# Altium schematic sheet 08_SOFT_DPHY.SchDoc(08_SOFT_DPHY)
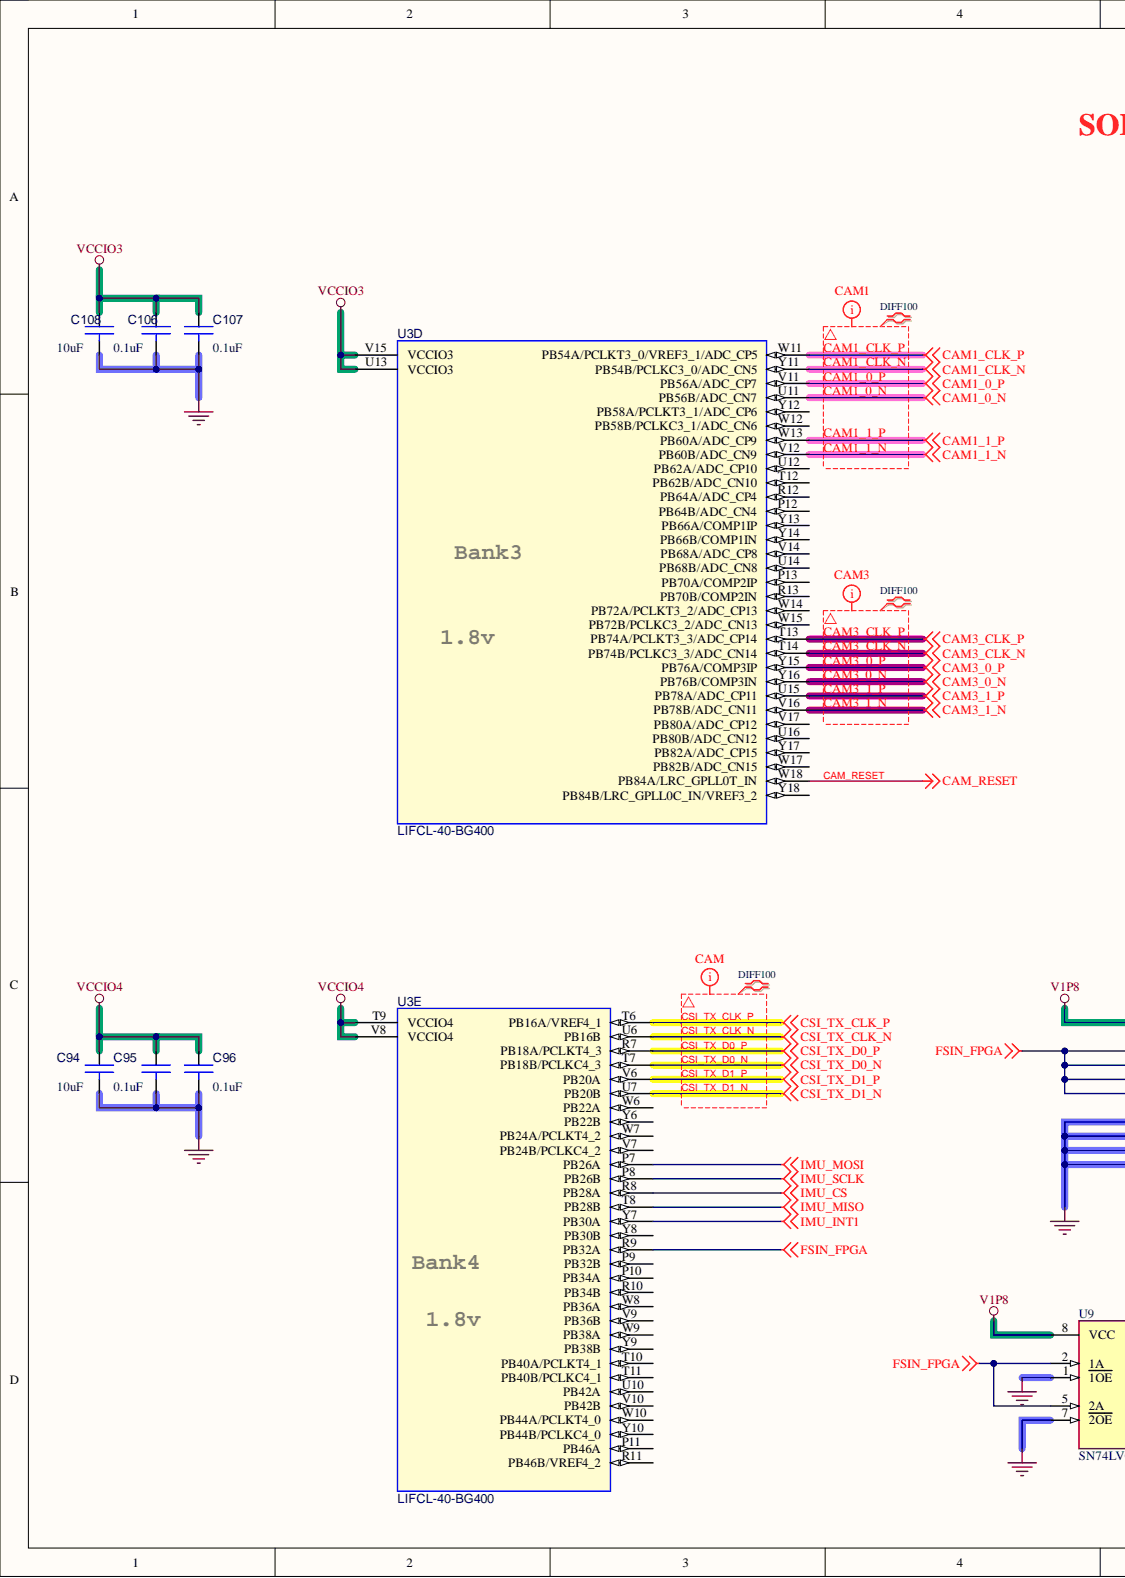
[diagram p0: 08_SOFT_DPHY.SchDoc, left]
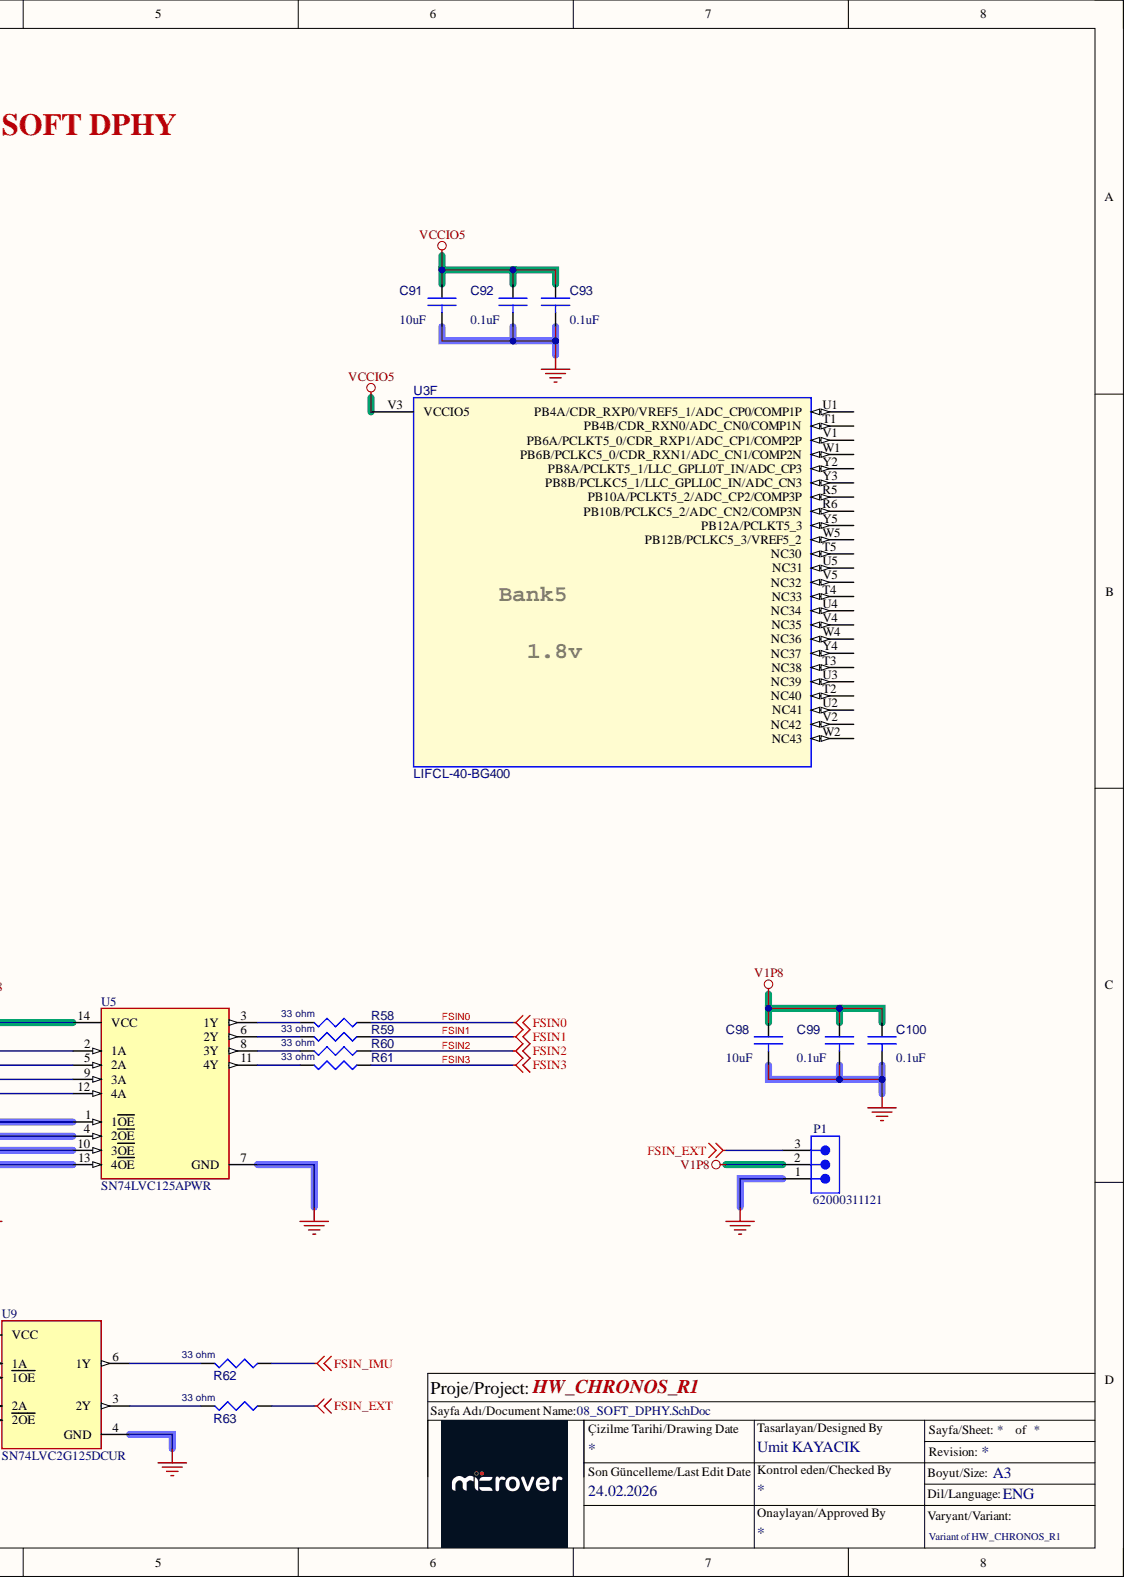
[diagram p1: 08_SOFT_DPHY.SchDoc, right]

## Parts
C91  10uF  Part Reference: C91  [C0603]
C92  0.1uF  Part Reference: C92  [C0201]
C93  0.1uF  Part Reference: C93  [C0201]
C94  10uF  Part Reference: C94  [C0603]
C95  0.1uF  Part Reference: C95  [C0201]
C96  0.1uF  Part Reference: C96  [C0201]
C98  10uF  [C0603]
C99  0.1uF  [C0201]
C100  0.1uF  [C0201]
C106  0.1uF  Part Reference: C106  [C0201]
C107  0.1uF  Part Reference: C107  [C0201]
C108  10uF  Part Reference: C108  [C0603]
P1  62000311121  [62000311121]
R58  33 ohm  [R0402]
R59  33 ohm  [R0402]
R60  33 ohm  [R0402]
R61  33 ohm  [R0402]
R62  33 ohm  [RLP-100]
R63  33 ohm  [R0402]
U3  LIFCL-40-BG400  Part Reference: U3  [LIFCL-40-BG400]  (parts D,E,F)
U5  SN74LVC125APWR  [FP-PW0014A-IPC_B]
U9  SN74LVC2G125DCUR  [FP-DCU0008A-MFG]

## Nets
FSIN_EXT: P1.3 R63.2
FSIN_FPGA: U3.R9(PB32A) U5.2(1A) U5.5(2A) U5.9(3A) U5.12(4A) U9.2(1A) U9.5(2A)
FSIN_IMU: R62.2
GND: C91.2 C92.2 C93.2 C94.2 C95.2 C96.2 C98.2 C99.2 C100.2 C106.2 C107.2 C108.2 P1.1 U5.1(1OE) U5.4(2OE) U5.7(GND) U5.10(3OE) U5.13(4OE) U9.1(1OE) U9.4(GND) U9.7(2OE)
IMU_CS: U3.R8(PB28A)
IMU_INT1: U3.Y7(PB30A)
IMU_MISO: U3.T8(PB28B)
IMU_MOSI: U3.P7(PB26A)
IMU_SCLK: U3.P8(PB26B)
V1P8: C98.1 C99.1 C100.1 P1.2 U5.14(VCC) U9.8(VCC)
VCCIO3: C106.1 C107.1 C108.1 U3.U13(VCCIO3) U3.V15(VCCIO3)
VCCIO4: C94.1 C95.1 C96.1 U3.T9(VCCIO4) U3.V8(VCCIO4)
VCCIO5: C91.1 C92.1 C93.1 U3.V3(VCCIO5)
CAM1_0_N: U3.U11(PB56B/ADC_CN7)
CAM1_0_P: U3.V11(PB56A/ADC_CP7)
CAM1_1_N: U3.V12(PB60B/ADC_CN9)
CAM1_1_P: U3.W13(PB60A/ADC_CP9)
CAM1_CLK_N: U3.Y11(PB54B/PCLKC3_0/ADC_CN5)
CAM1_CLK_P: U3.W11(PB54A/PCLKT3_0/VREF3_1/ADC_CP5)
CAM3_0_N: U3.Y16(PB76B/COMP3IN)
CAM3_0_P: U3.Y15(PB76A/COMP3IP)
CAM3_1_N: U3.V16(PB78B/ADC_CN11)
CAM3_1_P: U3.U15(PB78A/ADC_CP11)
CAM3_CLK_N: U3.T14(PB74B/PCLKC3_3/ADC_CN14)
CAM3_CLK_P: U3.T13(PB74A/PCLKT3_3/ADC_CP14)
CAM_RESET: U3.W18(PB84A/LRC_GPLL0T_IN)
CSI_TX_CLK_N: U3.U6(PB16B)
CSI_TX_CLK_P: U3.T6(PB16A/VREF4_1)
CSI_TX_D0_N: U3.T7(PB18B/PCLKC4_3)
CSI_TX_D0_P: U3.R7(PB18A/PCLKT4_3)
CSI_TX_D1_N: U3.U7(PB20B)
CSI_TX_D1_P: U3.V6(PB20A)
FSIN0: R58.2
FSIN1: R59.2
FSIN2: R60.2
FSIN3: R61.2
NetR58_1: R58.1 U5.3(1Y)
NetR59_1: R59.1 U5.6(2Y)
NetR60_1: R60.1 U5.8(3Y)
NetR61_1: R61.1 U5.11(4Y)
NetR62_1: R62.1 U9.6(1Y)
NetR63_1: R63.1 U9.3(2Y)

Not connected: U3 P9(PB32B) P10(PB34A) P11(PB46A) P12(PB64B/ADC_CN4) P13(PB70A/COMP2IP) R5(PB10A/PCLKT5_2/ADC_CP2/COMP3P) R6(PB10B/PCLKC5_2/ADC_CN2/COMP3N) R10(PB34B) R11(PB46B/VREF4_2) R12(PB64A/ADC_CP4) R13(PB70B/COMP2IN) T1(PB4B/CDR_RXN0/ADC_CN0/COMP1N) T2(NC40) T3(NC38) T4(NC33) T5(NC30) T10(PB40A/PCLKT4_1) T11(PB40B/PCLKC4_1) T12(PB62B/ADC_CN10) U1(PB4A/CDR_RXP0/VREF5_1/ADC_CP0/COMP1P) U2(NC41) U3(NC39) U4(NC34) U5(NC31) U10(PB42A) U12(PB62A/ADC_CP10) U14(PB68B/ADC_CN8) U16(PB80B/ADC_CN12) V1(PB6A/PCLKT5_0/CDR_RXP1/ADC_CP1/COMP2P) V2(NC42) V4(NC35) V5(NC32) V7(PB24B/PCLKC4_2) V9(PB36B) V10(PB42B) V14(PB68A/ADC_CP8) V17(PB80A/ADC_CP12) W1(PB6B/PCLKC5_0/CDR_RXN1/ADC_CN1/COMP2N) W2(NC43) W4(NC36) W5(PB12B/PCLKC5_3/VREF5_2) W6(PB22A) W7(PB24A/PCLKT4_2) W8(PB36A) W9(PB38A) W10(PB44A/PCLKT4_0) W12(PB58B/PCLKC3_1/ADC_CN6) W14(PB72A/PCLKT3_2/ADC_CP13) W15(PB72B/PCLKC3_2/ADC_CN13) W17(PB82B/ADC_CN15) Y2(PB8A/PCLKT5_1/LLC_GPLL0T_IN/ADC_CP3) Y3(PB8B/PCLKC5_1/LLC_GPLL0C_IN/ADC_CN3) Y4(NC37) Y5(PB12A/PCLKT5_3) Y6(PB22B) Y8(PB30B) Y9(PB38B) Y10(PB44B/PCLKC4_0) Y12(PB58A/PCLKT3_1/ADC_CP6) Y13(PB66A/COMP1IP) Y14(PB66B/COMP1IN) Y17(PB82A/ADC_CP15) Y18(PB84B/LRC_GPLL0C_IN/VREF3_2)

## Library data of the parts
C91 C94 C108 = 10uF_0603: CAP CECAP CER 10UF 10V X5R 20% 0603; Manufacturer: Taiyo Yuden; Manufacturer Part Number: LMK107BJ106MALTD; Class: Discrete; Assy: -; Component Kind: Standard; Digikey P/N: 587-2562-1-ND; PCB Footprint: C0603; Value: 10uF
C92 C93 C95 C96 C106 C107 = 0u1_0402: CAP CER 0.1UF 25V 10% X5R 0201; Manufacturer: Murata; Manufacturer Part Number: GRM033R61E104KE14J; Class: Discrete; Assy: -; Component Kind: Standard; Digikey P/N: 399-3521-2-ND; PCB Footprint: C0201; Value: 0.1uF
C98 = 10uF_0603: CAP CECAP CER 10UF 10V X5R 20% 0603; Manufacturer: Taiyo Yuden; Manufacturer Part Number: LMK107BJ106MALTD; Part Reference: C91; Class: Discrete; Assy: -; Component Kind: Standard; Digikey P/N: 587-2562-1-ND; PCB Footprint: C0603; Value: 10uF
C99 = 0u1_0402: CAP CER 0.1UF 25V 10% X5R 0201; Manufacturer: Murata; Manufacturer Part Number: GRM033R61E104KE14J; Part Reference: C92; Class: Discrete; Assy: -; Component Kind: Standard; Digikey P/N: 399-3521-2-ND; PCB Footprint: C0201; Value: 0.1uF
C100 = 0u1_0402: CAP CER 0.1UF 25V 10% X5R 0201; Manufacturer: Murata; Manufacturer Part Number: GRM033R61E104KE14J; Part Reference: C93; Class: Discrete; Assy: -; Component Kind: Standard; Digikey P/N: 399-3521-2-ND; PCB Footprint: C0201; Value: 0.1uF
P1 = CMP-1502-00957-5: THT Vertical Pin Header WR-PHD, Pitch 2.00 mm, Single Row, 3 pins; Contact Resistance: 20mΩ; Current Rating: 2A; Gender: Male; Number of Contacts: 3; Operating Supply Voltage: 200V; Component Kind: Standard; Contact Material: Gold; Height: 6.35mm; Lead Pitch: 2mm; Library Name: Altium Content Vault; Max Operating Temperature: 105°C; Min Operating Temperature: -40°C; Number of Pins: 3; Number of Rows: 1; Orientation: Vertical; RoHS: Compliant; Voltage Rating: 200V
R58-R63 = RES_100K_1W16_0402_5P: RES SMD 33 OHM 1% 1/20W 0201; Manufacturer: Yageo; Part Reference: R27; Assy: DNI; DIST: FLAT; MAX_TEMP: RTMAX; PCB Footprint: R0201; TC1: 0; Total Area(sq-mm): 0.5; Unit Price (USD): 0.02; Wattage: 1/16W; Component Kind: Standard; Length(mm): 1; MFG: Yageo; TC2: 0; Value: 33 ohm; Width(mm): 0.5
U3 = LIFCL-40-BG400: Graphic: LIFCL-40-BG400A.Normal; Location X-Coordinate: 0; Name: I17266603; Power Pins Visible: False; Primitive: DEFAULT; Source Library: C:\USERS\SLIU1\DOCUMENTS\PCB_DESIGN\JEDI_EVAL_BOARD\SCHEMATIC\LIFCL40.OLB; SPLIT_INST: TRUE; SWAP_INFO: (S1+S2+S3+S4+S5+S6+S7+S8+S9+S10+S11+S12+S13+S14+S15); Implementation Type: <none>; Assy: -; Color: Default; Component Kind: Standard; Location Y-Coordinate: 0; PCB Footprint: LIFCL-40-BG400; Source Package: LIFCL-40-BG400; Source Part: LIFCL-40-BG400A.Normal; Value: LIFCL-40-BG400
U5 = CMP-0440-00179-4: IC BUF NON-INVERT 3.6V 14TSSOP; High Level Output Current: -24mA; Logic Function: Buffer; Low Level Output Current: 24mA; Max Power Dissipation: 500mW; Min Supply Voltage: 1.65V; Number of Bits: 4; Number of Bits per Element: 1; Number of Output Lines: 3; Polarity: Non-Inverting; Propagation Delay: 4.6ns; Quiescent Current: 1uA; Turn-On Delay Time: 5.3ns; Case/Package: TSSOP; Component Kind: Standard; Contact Plating: Gold; Height: 1.15mm; Lead Free: Lead Free; Length: 5mm; Library Name: Altium Content Vault; Max Operating Temperature: 125°C; Max Supply Voltage: 3.6V; Min Operating Temperature: -40°C; Mount: Surface Mount; Number of Channels: 4; Number of Circuits: 4; Number of Elements: 4; Number of Gates: 4; Number of Outputs: 4; Number of Pins: 14; Number of Input Lines: 4; Number of Inputs: 4; Package Quantity: 1; Packaging: Tape and Reel; Radiation Hardening: No; REACH SVHC: No SVHC; Termination: SMD/SMT; Width: 4.4mm
U9 = CMP-0859-00680-4: IC BUFFER NON-INVERT 5.5V US8; Manufacturer: Texas Instruments; Logic Function Desc: Non-Inverting; Max Junction Temp: +150°C; Min Supply Voltage: 1.65V; Number Of Circuits: 2; Number Of Outputs: 1; Propagation Delay: 4.2ns; Standoff Height: 0mm; Ambient Temperature Range High: +125°C; Ambient Temperature Range Low: -40°C; Automotive: No; Category: IC; Component Kind: Standard; ComponentLink2Description: Datasheet; ComponentLink2URL: http://www.ti.com/lit/ds/symlink/sn74lvc2g125.pdf; Device Class L1: Integrated Circuits (ICs); Device Class L2: Logic ICs; Device Class L3: Buffers; Digikey Description: IC BUFFER NON-INVERT 5.5V US8; Height: 0.9mm; Lead Free: Yes; Library Name: Altium Content Vault; Manufacturer Part Number: SN74LVC2G125DCUR; Max Supply Voltage: 5.5V; Mouser Description: Buffers & Line Drivers Tri-State Dual Bus; Number Of Inputs: 1; Octopart: https://octopart.com/sn74lvc2g125dcur-texas+instruments-464564; Package: DCU0008A; RoHS: TRUE; Temperature: -40°C to +125°C; Temperature Range High: +125°C; Temperature Range Low: -40°C; Ipc Land Pattern Name: SOP50P310X75-8
